# Altium schematic sheet DIYstortion.SchDoc
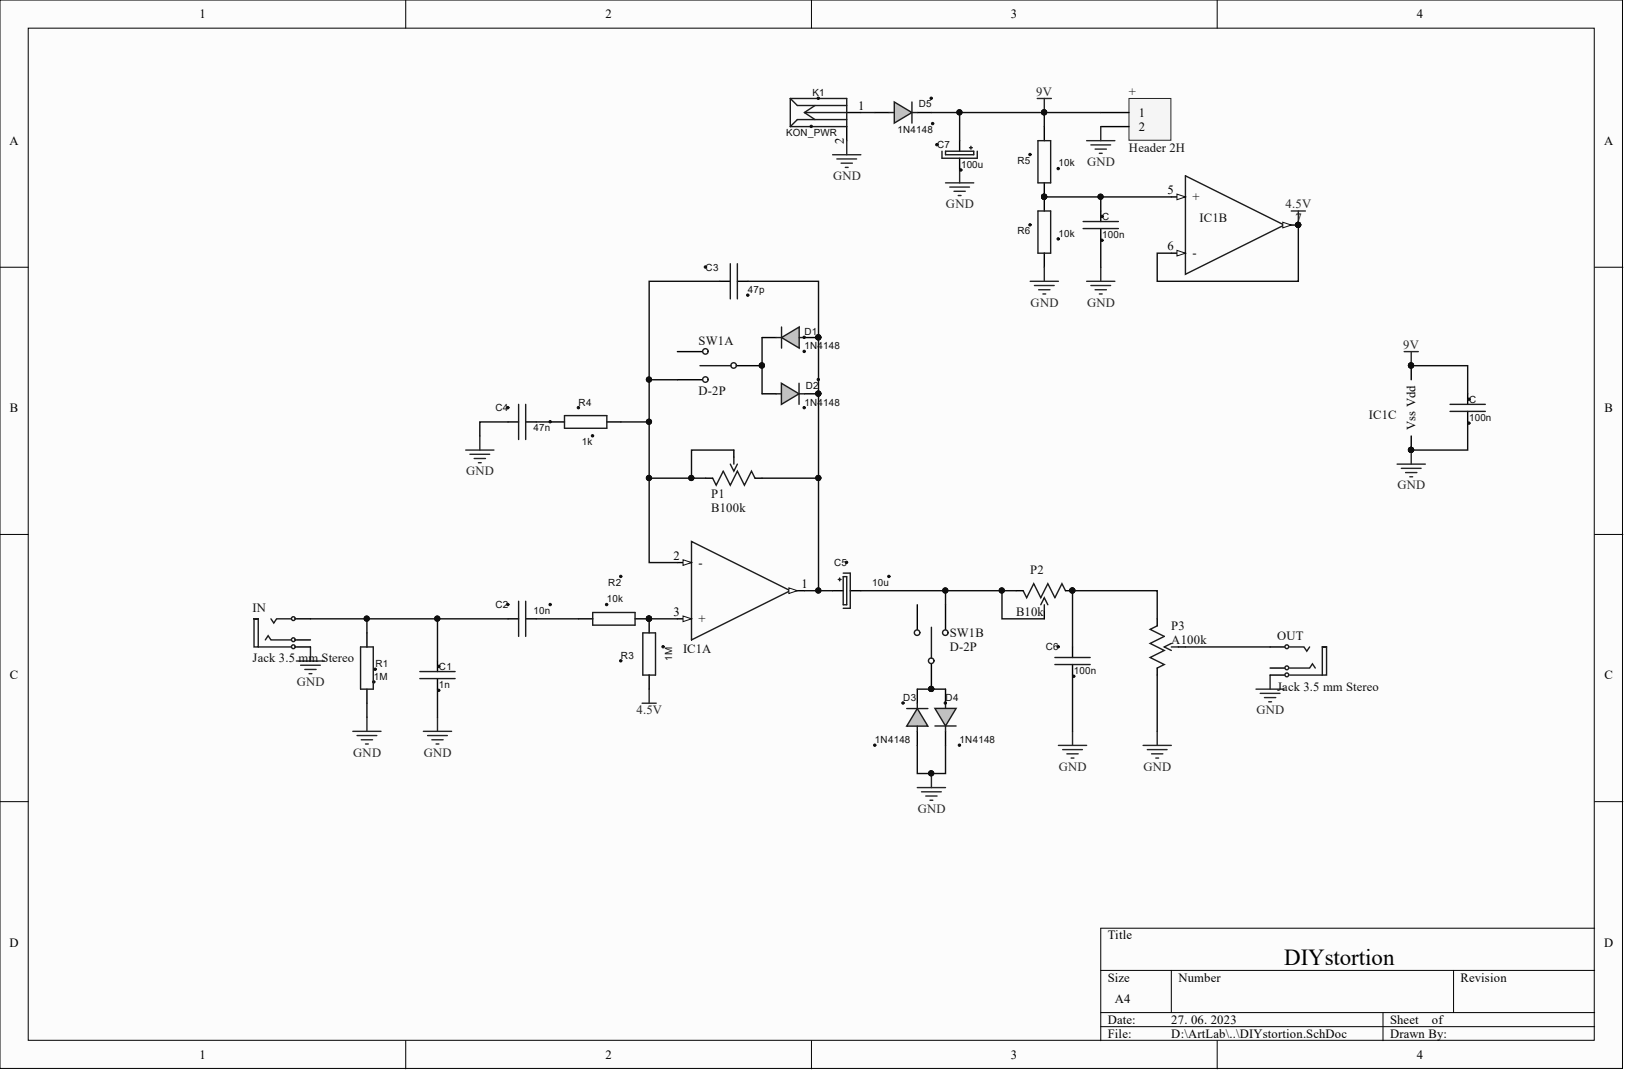
[diagram p0: DIYstortion.SchDoc]

## Parts
+  Header 2H  [HDR1X2H]
C  100n  [C Square R5.08 (200mil)]
C  100n  [C Square R5.08 (200mil)]
C1  1n  [C Square R5.08 (200mil)]
C2  10n  [C Square R5.08 (200mil)]
C3  value 47p  [C Square R5.08 (200mil)]  (hidden comment: 10n)
C4  value 47n  [C Square R5.08 (200mil)]  (hidden comment: 10n)
C5  10u  [C AlElko R2.54 FI5]
C6  100n  [C Square R5.08 (200mil)]
C7  100u  [C AlElko R2.54 FI5]
D1  1N4148  [DO 35 (300MILS)]
D2  1N4148  [DO 35 (300MILS)]
D3  1N4148  [DO 35 (300MILS)]
D4  1N4148  [DO 35 (300MILS)]
D5  1N4148  [DO 35 (300MILS)]
IC1  TL072  [DIP8]  (value not drawn)  (parts A,B,C)
IN  Jack 3.5 mm Stereo  [FC68131]
K1  KON_PWR  [_KON_PWR_KON_PWR(Primary)]
OUT  Jack 3.5 mm Stereo  [FC68131]
P1  B100k  [PDB181- P]
P2  B10k  [PDB181- P]
P3  A100k  [PDB181- P]
R1  1M  [R300]
R2  10k  [R300]
R3  1M  [R300]
R4  value 1k  [R300]  (hidden comment: 1M)
R5  10k  [R300]
R6  10k  [R300]
SW1  D-2P  [D-2P]  (parts A,B)

## Nets
4.5V: IC1.6(-) IC1.7 R3.1
9V: +.1 C.1 C7.1 D5.K IC1.8(Vdd) R5.2
GND: +.2 C.2 C1.2 C4.1 C6.2 C7.2 D3.A D4.K IC1.4(Vss) IN.1 K1.2 OUT.1 P3.1 R1.2 R6.1
NetC1_1: C1.1 C2.1 IN.5 R1.1
NetC2_2: C2.2 R2.1
NetC3_1: C3.1 C5.1 D1.A D2.K IC1.1 P1.1
NetC3_2: C3.2 IC1.2(-) P1.2 P1.3 R4.2 SW1.1
NetC4_2: C4.2 R4.1
NetC5_2: C5.2 P2.1 P2.2 SW1.6
NetC6_1: C6.1 P2.3 P3.3
NetC_1: C.1 IC1.5(+) R5.1 R6.2
NetD1_K: D1.K D2.A SW1.2
NetD3_K: D3.K D4.A SW1.5
NetD5_A: D5.A K1.1
NetIC1_3: IC1.3(+) R2.2 R3.2
NetOUT_5: OUT.5 P3.2

Not connected: IN 2; OUT 2; SW1 3 4

## Library data of the parts
+ = Header 2H: Header, 2-Pin, Right Angle; Component Kind: Standard; LatestRevisionDate: 17-Jul-2002; LatestRevisionNote: Re-released for DXP Platform.; Library Name: Miscellaneous Connectors.IntLib; Signal Integrity: Connector; Publisher: Altium Limited
C C C1-C4 C6 = C: Capacitor; Component Kind: Standard; Library Name: General.IntLib
  C C C6: Value: 100n
  C1: Value: 1n
  C2: Value: 10n
C5 C7 = C+: Capacitor Polarized; Component Kind: Standard; Library Name: General.IntLib
  C5: Value: 10u
  C7: Value: 100u
IC1 = TL072: J-FET OP AMP; Component Kind: Standard; Library Name: Eurorack.IntLib
IN OUT = Stereo Jack 3.5 mm 3-pin: Jack 3.5 mm PCB mount 3-pin Stereo; Component Kind: Standard; ComponentLink1Description: Datasheet; ComponentLink1URL: https://si.farnell.com/cliff-electronic-components/fc68131/stereo-jack-3-5mm-3pos-pcb/dp/2518188; ComponentLink1URL: http://si.farnell.com/webapp/wcs/stores/servlet/ProductDisplay?catalogId=10001&langId=386&urlRequestType=Base&partNumber=1267400&storeId=10175; Library Name: Eurorack.IntLib
P1 P3 = Potentiometer Rotary: Value: {Value}; Component Kind: Standard; ComponentLink1description: datasheet; ComponentLink1URL: https://www.bourns.com/docs/product-datasheets/PDB18.pdf; ComponentLink1URL: http://si.farnell.com/webapp/wcs/stores/servlet/Search?catalogId=15001&langId=386&storeId=10175&categoryId=700000005476&sort=P_PRICE&pageSize=25&beginIndex=1&showResults=true&pf=110131914,113164069; Description: Potentiometer Rotary; Library Name: Eurorack.IntLib
P2 = Potentiometer Rotary: Component Kind: Standard; ComponentLink1description: datasheet; ComponentLink1URL: https://www.bourns.com/docs/product-datasheets/PDB18.pdf; ComponentLink1URL: http://si.farnell.com/webapp/wcs/stores/servlet/Search?catalogId=15001&langId=386&storeId=10175&categoryId=700000005476&sort=P_PRICE&pageSize=25&beginIndex=1&showResults=true&pf=110131914,113164069; Description: Potentiometer Rotary; Value: B10k; Library Name: Eurorack.IntLib
R1-R6 = R: Component Kind: Standard; Description: R; Library Name: General.IntLib
  R1 R3: Value: 1M
  R2 R5 R6: Value: 10k
SW1 = SW D-2P: Toggle Switch, D-2P, PCB; Component Kind: Standard; Library Name: Eurorack.IntLib
D1-D5 = 1N4148: Component Kind: Standard; Description: 1N4148; Library Name: SemiCond.IntLib
K1 = KON_PWR: Component Kind: Standard; Description: KON_PWR; Library Name: Kon_Tipk.IntLib
